# Altium schematic sheet Sheet2_v2.SchDoc
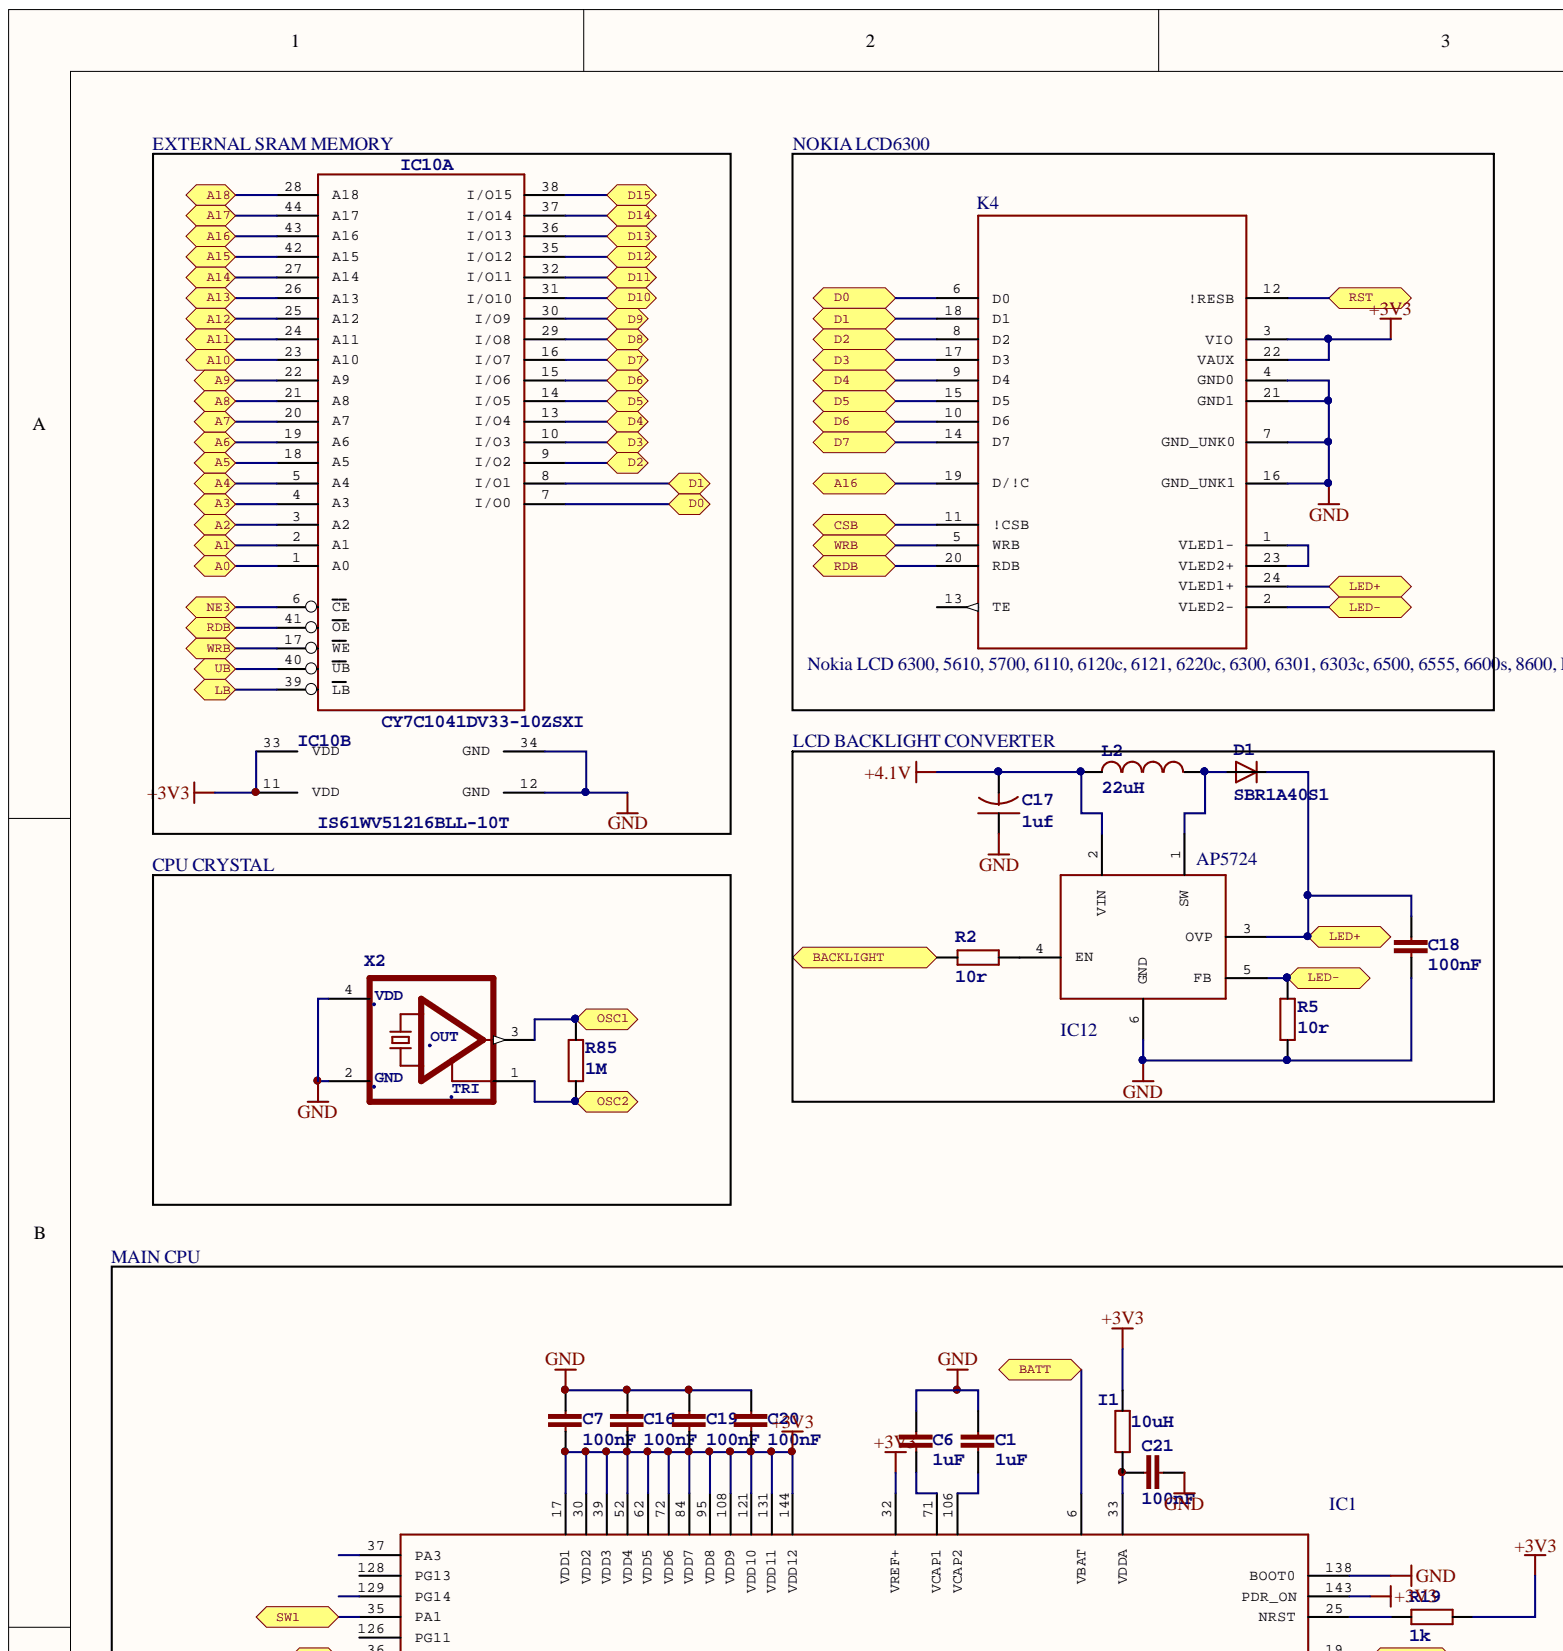
[diagram p0: Sheet2_v2.SchDoc, top left]
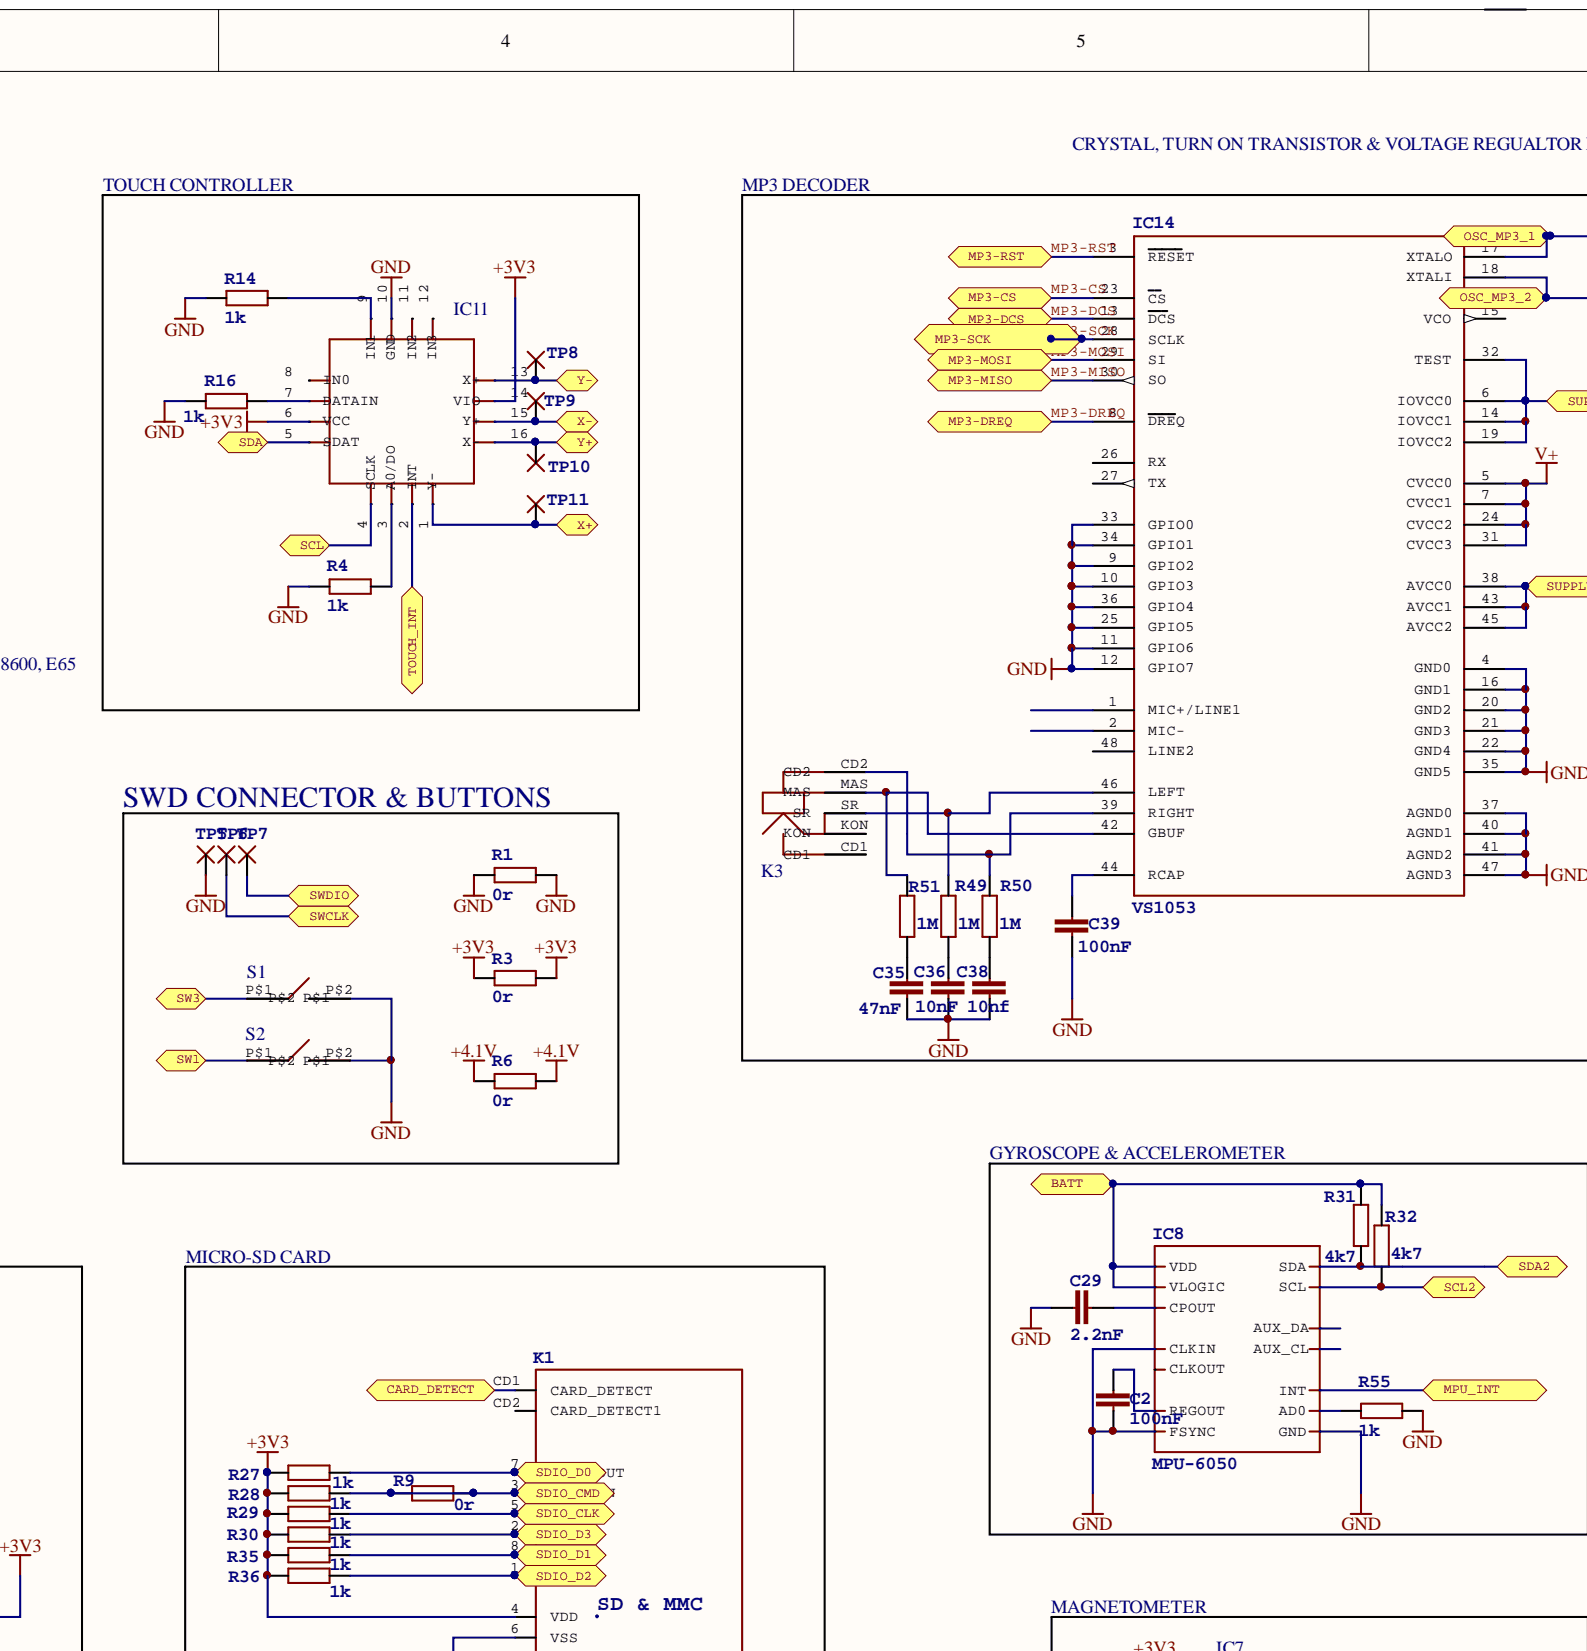
[diagram p1: Sheet2_v2.SchDoc, top centre]
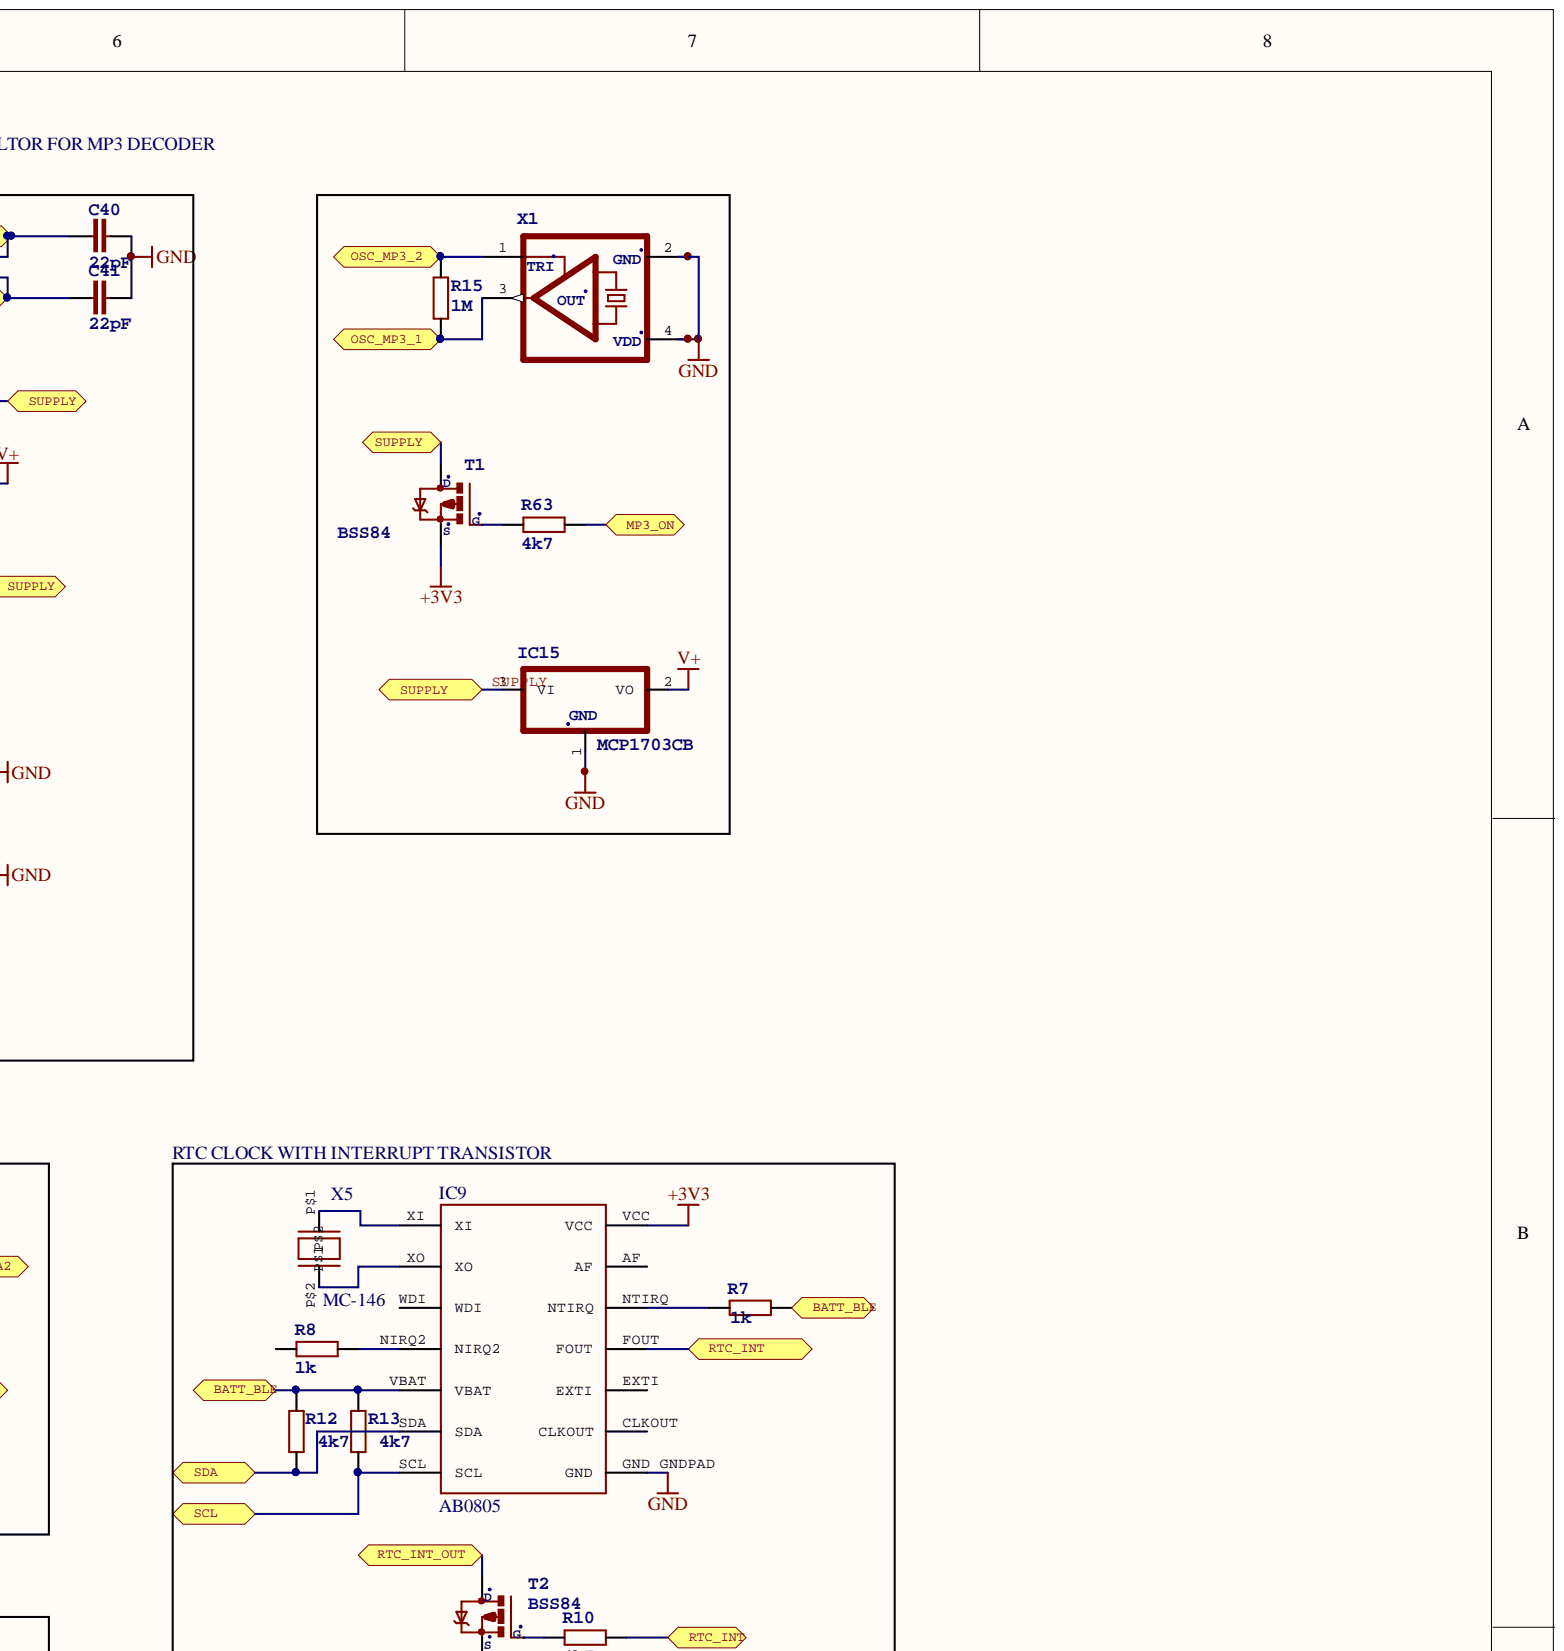
[diagram p2: Sheet2_v2.SchDoc, top right]
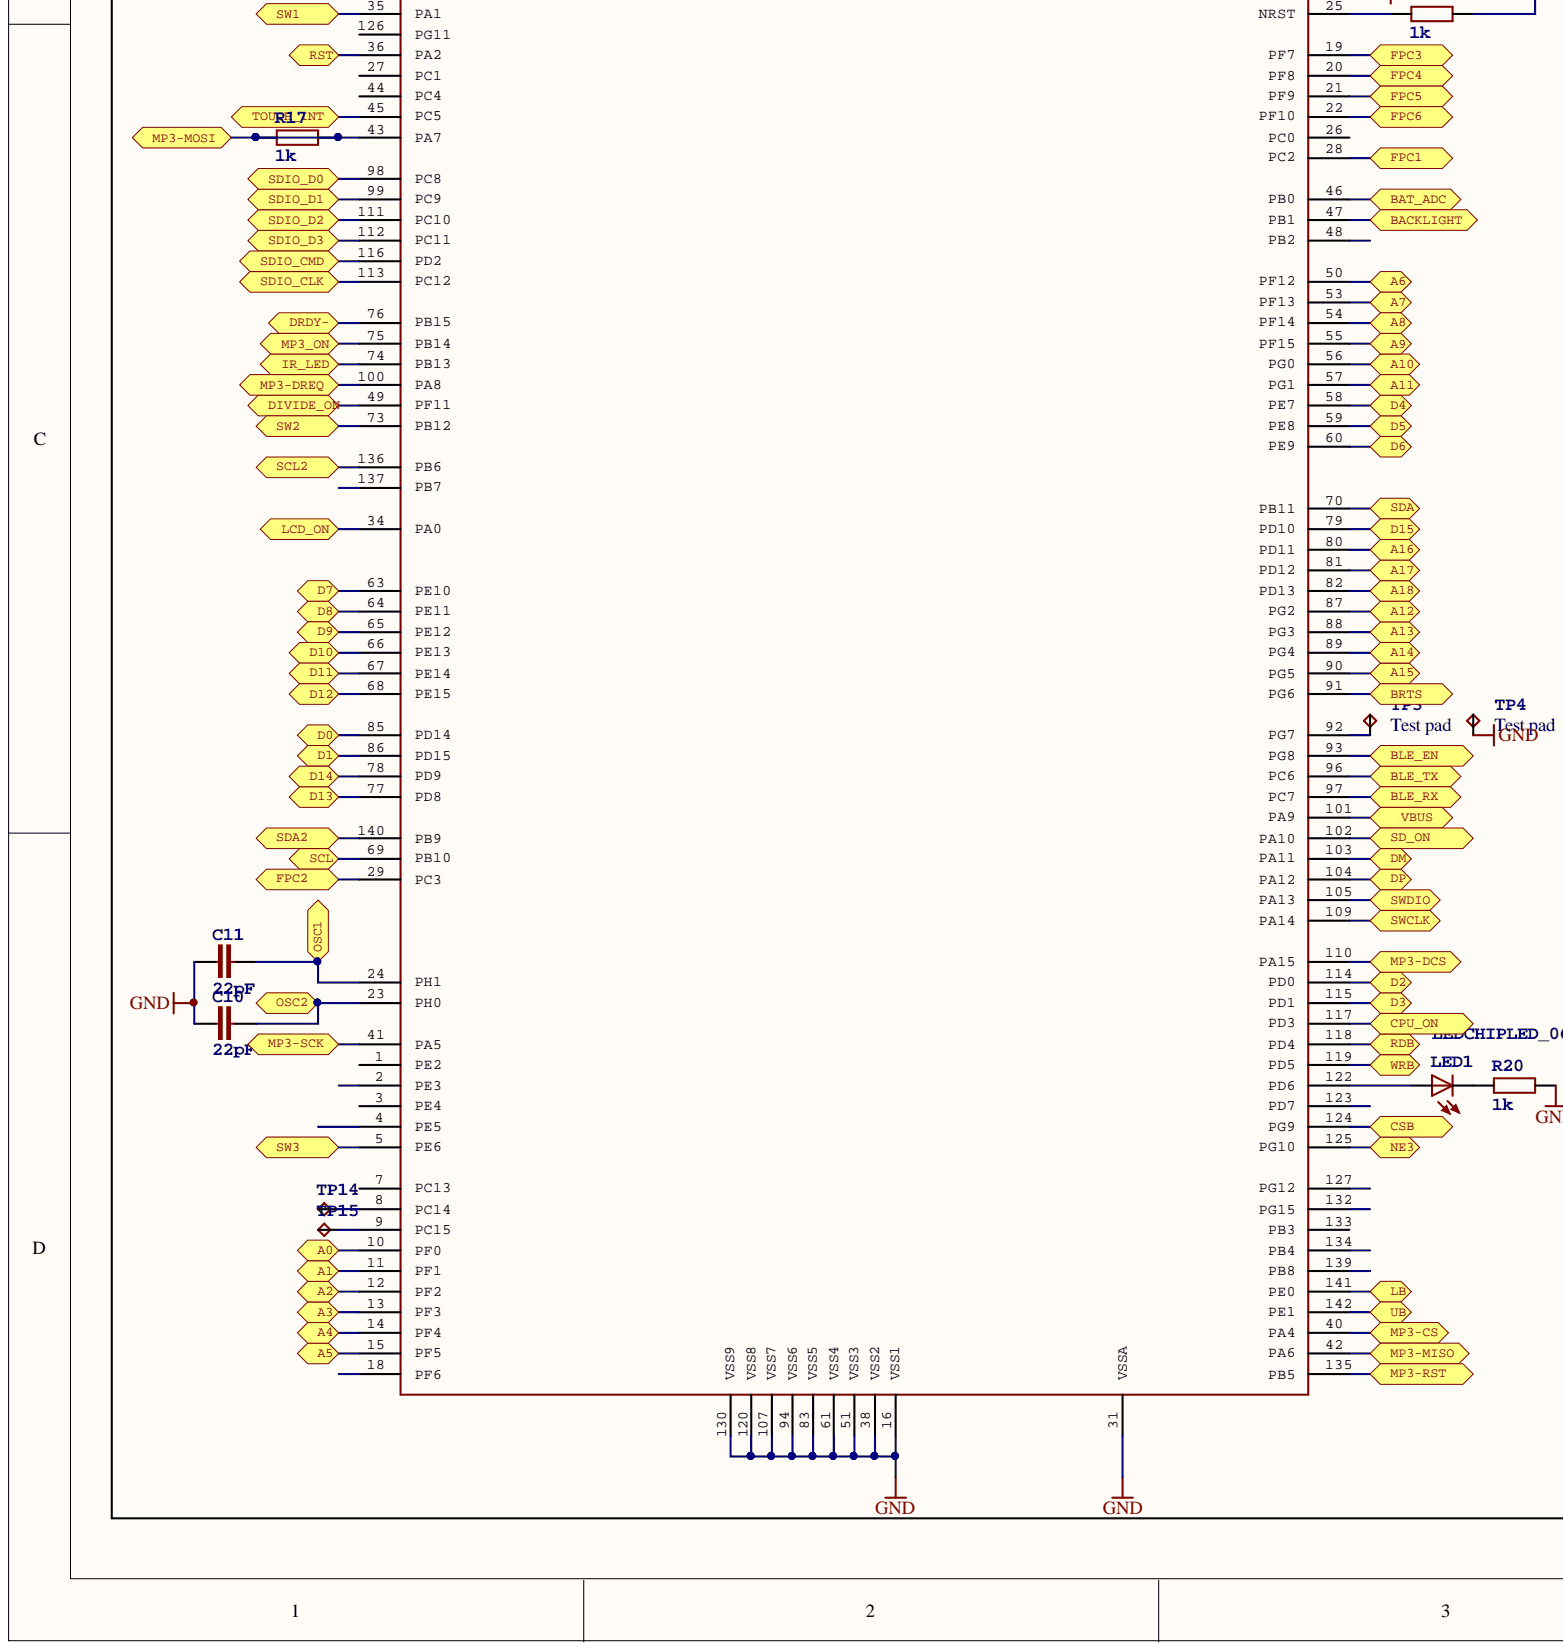
[diagram p3: Sheet2_v2.SchDoc, bottom left]
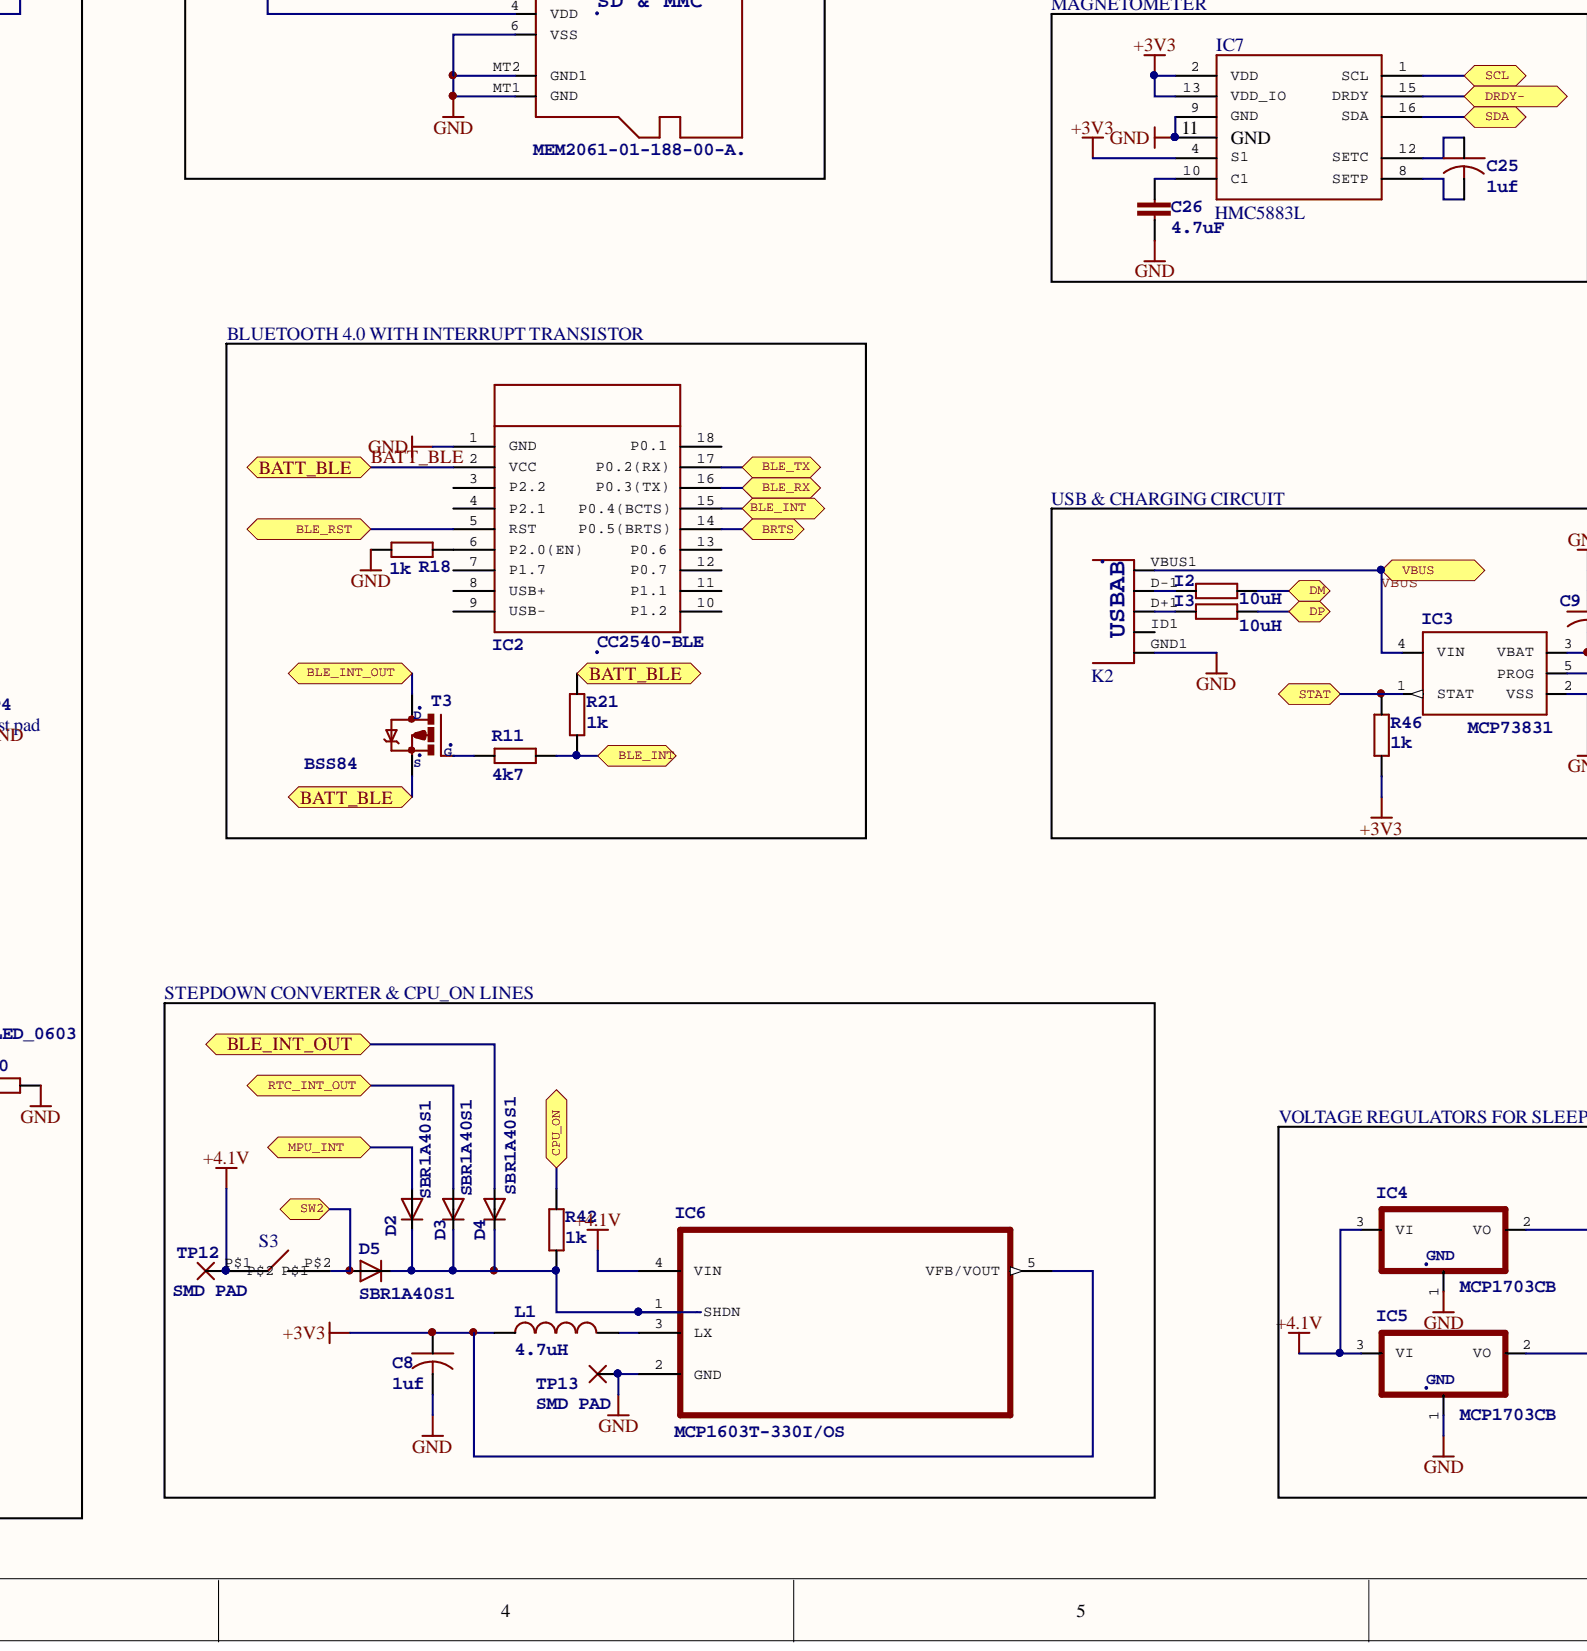
[diagram p4: Sheet2_v2.SchDoc, bottom centre]
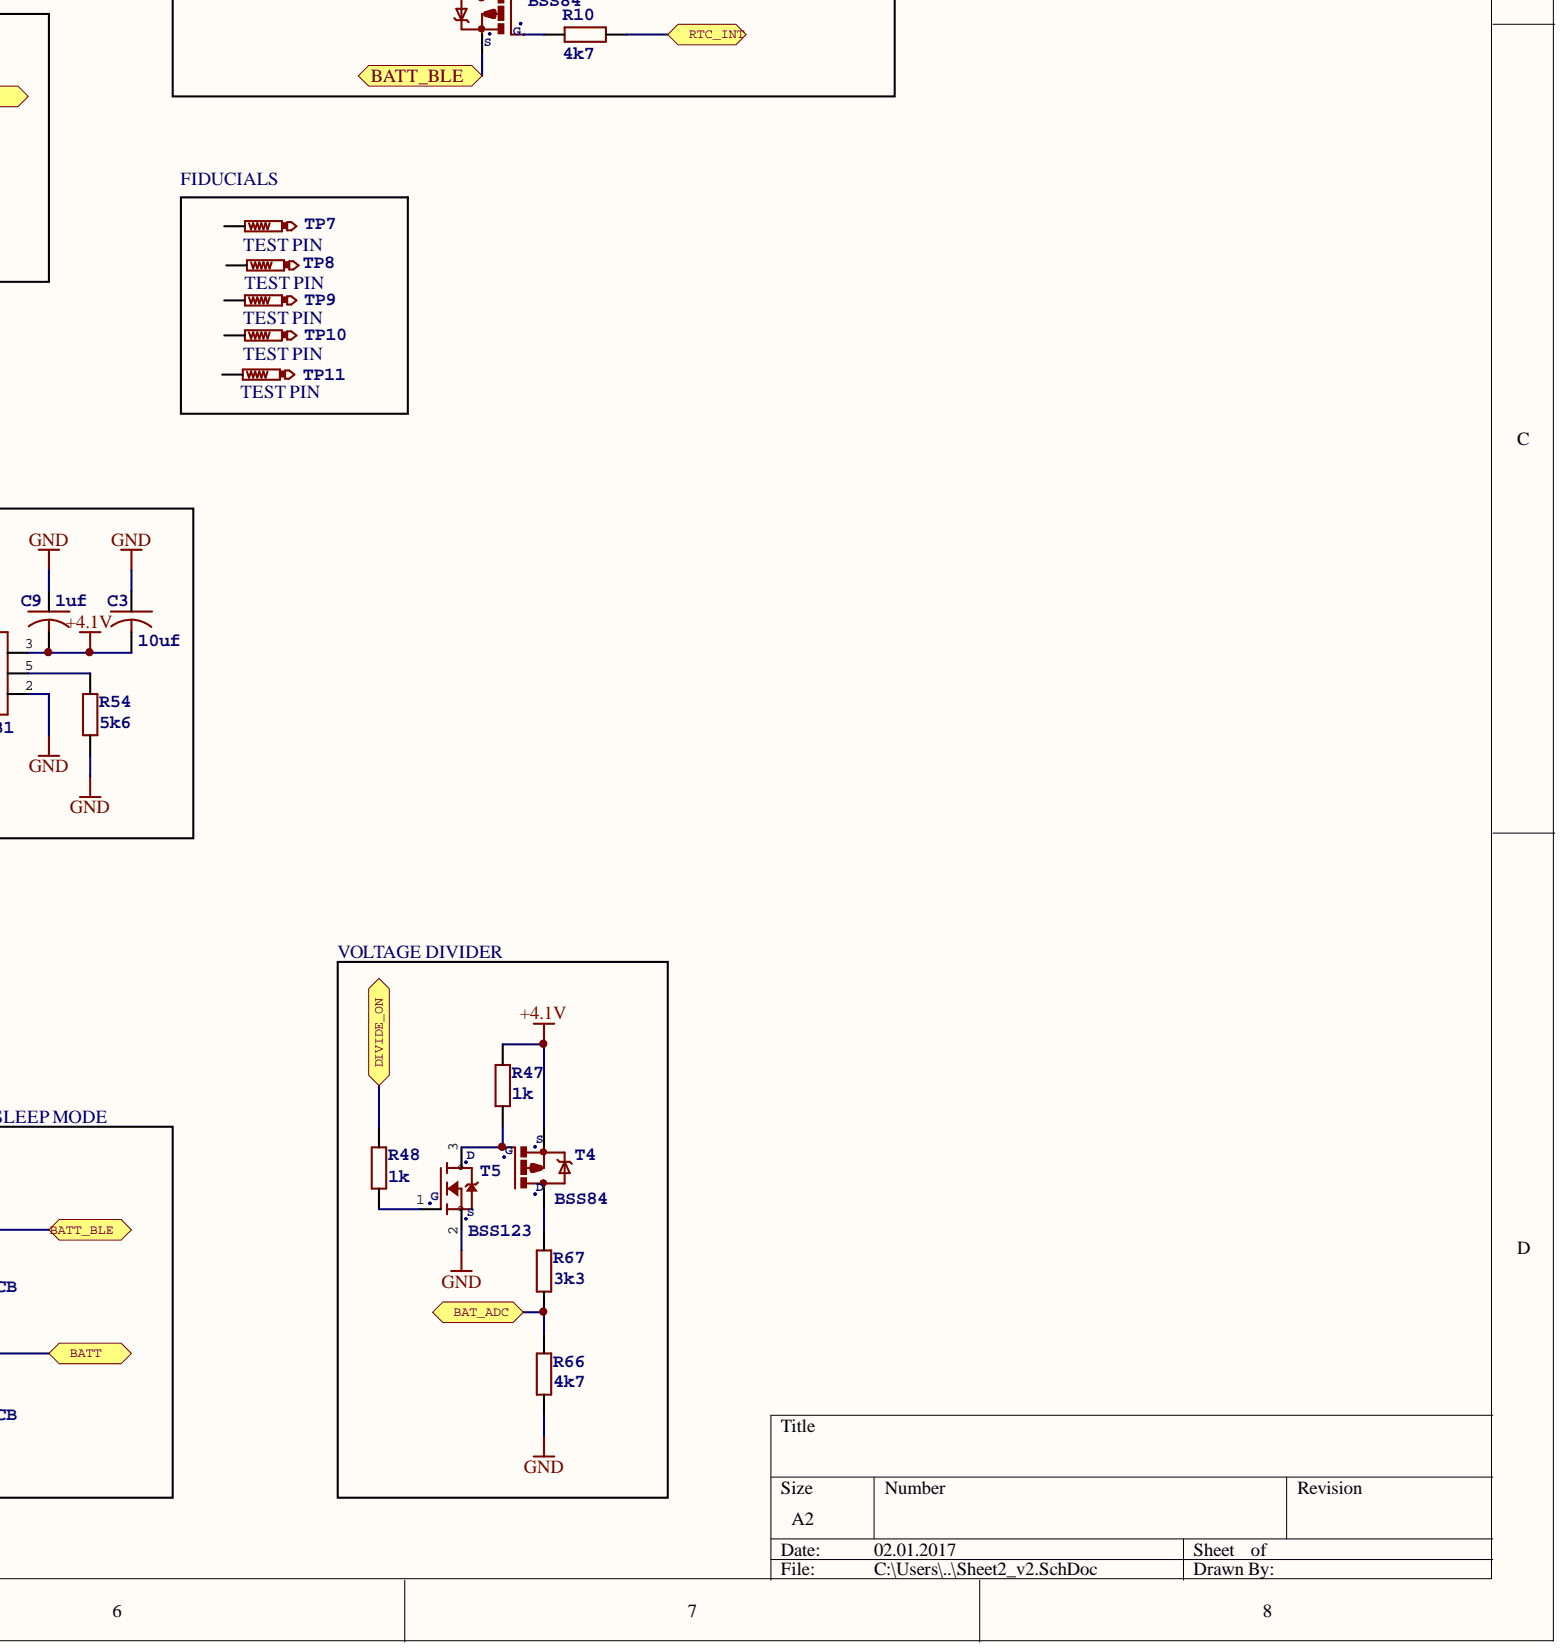
[diagram p5: Sheet2_v2.SchDoc, bottom right]

## Parts
C1  1uF  [C0402]
C2  100nF  [C0402]
C3  10uf  [C0805K]
C6  1uF  [C0402]
C7  100nF  [C0402]
C8  1uf  [C0805K]
C9  1uf  [C0805K]
C10  22pF  [C0402]
C11  22pF  [C0402]
C16  100nF  [C0402]
C17  1uf  [C0805K]
C18  100nF  [C0402]
C19  100nF  [C0402]
C20  100nF  [C0402]
C21  100nF  [C0402]
C25  1uf  [C0805K]
C26  4.7uF  [C0603K]
C29  2.2nF  [C0603K]
C35  47nF  [C0402]
C36  10nF  [C0402]
C38  10nf  [C0402]
C39  100nF  [C0402]
C40  22pF  [C0402]
C41  22pF  [C0402]
D1  SBR1A40S1  [SOD123]
D2  SBR1A40S1  [SOD123]
D3  SBR1A40S1  [SOD123]
D4  SBR1A40S1  [SOD123]
D5  SBR1A40S1  [SOD123]
I1  10uH  [R0402]
I2  10uH  [R0402]
I3  10uH  [R0402]
IC1  STM32F407ZGT6  [QFP50P2000X2000X160-144N]  (value not drawn)
IC2  RF-BM-S02  [CC2540-MINI-BLE]  (value not drawn)
IC3  MCP73831  [SOT23-5]
IC4  MCP1703CB  [SOT23]
IC5  MCP1703CB  [SOT23]
IC6  MCP1603T-330I/OS  [SOT95P280X110-5N]
IC7  HMC5883L  [LPCC16]
IC8  MPU-6050  [QFN.24.4X4]
IC9  AB0805  [QFN16]
IC10  CY7C1041DV33-10ZSXI  [TSOP-II-44]  (parts A,B)
IC11  STMPE811  [QFN-16]  (value not drawn)
IC12  AP5724  [DFN-62X2]
IC14  VS1053  [LQFP-48]
IC15  MCP1703CB  [SOT23]
K1  MEM2061-01-188-00-A.  SD&MMC: SD & MMC  [MICROSD]
K2  Microusb type B connector  [USB-MICRO-SMD]  (value not drawn)
K3  MOJ_JACK  [MOJ_JACK]  (value not drawn)
K4  Nokia LCD 6300, 5610, 5700, 6110, 6120c, 6121, 6220c, 6300, 6301, 6303c, 6500, 6555, 6600s, 8600, E65  [N6300_LCD_CONN]
L1  4.7uH  [L1812]
L2  22uH  [L1812]
LED1  LEDCHIPLED_0603  [CHIPLED_0603]
R1  0r  [R0402]
R2  10r  [R0603]
R3  0r  [R0402]
R4  1k  [R0402]
R5  10r  [R0603]
R6  0r  [R0402]
R7  1k  [R0402]
R8  1k  [R0402]
R9  0r  [R0402]
R10  4k7  [R0402]
R11  4k7  [R0402]
R12  4k7  [R0402]
R13  4k7  [R0402]
R14  1k  [R0402]
R15  1M  [R0402]
R16  1k  [R0402]
R17  1k  [R0402]
R18  1k  [R0402]
R19  1k  [R0402]
R20  1k  [R0402]
R21  1k  [R0402]
R27  1k  [R0402]
R28  1k  [R0402]
R29  1k  [R0402]
R30  1k  [R0402]
R31  4k7  [R0402]
R32  4k7  [R0402]
R35  1k  [R0402]
R36  1k  [R0402]
R42  1k  [R0402]
R46  1k  [R0402]
R47  1k  [R0402]
R48  1k  [R0402]
R49  1M  [R0402]
R50  1M  [R0402]
R51  1M  [R0402]
R54  5k6  [R0402]
R55  1k  [R0402]
R63  4k7  [R0402]
R66  4k7  [R0402]
R67  3k3  [R0402]
R85  1M  [R0402]
S1  TACTDR345H40B160  [SWITCHSMD]  (value not drawn)
S2  TACTDR345H40B160  [SWITCHSMD]  (value not drawn)
S3  TACTDR345H40B160  [SWITCHSMD]  (value not drawn)
T1  BSS84  [MICRO3]
T2  BSS84  [MICRO3]
T3  BSS84  [MICRO3]
T4  BSS84  [MICRO3]
T5  BSS123  [SOT23]
TP3  Test pad  [TP20R]
TP4  Test pad  [TP20R]
TP5  (no value)  [SMD1,27-2,54]
TP6  (no value)  [SMD1,27-2,54]
TP7  TEST PIN  [B1,27]
TP7  (no value)  [SMD1,27-2,54]
TP8  TEST PIN  [B1,27]
TP8  SMD PAD  [SMD1,27-2,54]  (value not drawn)
TP9  TEST PIN  [B1,27]
TP9  SMD PAD  [SMD1,27-2,54]  (value not drawn)
TP10  TEST PIN  [B1,27]
TP10  SMD PAD  [SMD1,27-2,54]  (value not drawn)
TP11  TEST PIN  [B1,27]
TP11  SMD PAD  [SMD1,27-2,54]  (value not drawn)
TP12  SMD PAD  [SMD2,54-5,08]
TP13  SMD PAD  [SMD2,54-5,08]
TP14  TPTP08SQ  [TP08SQ]  (value not drawn)
TP15  TPTP08SQ  [TP08SQ]  (value not drawn)
X1  X1G0041710019 SG-210STF 12.288MHZ  [ASE]  (value not drawn)
X2  E3SB16.0000F09G11AE  [ASE]  (value not drawn)
X5  MC-146  [CRYSTAL32.76KHZ]

## Ports
A0, A1, A2, A3, A4, A5, A6, A7, A8, A9, A10, A11, A12, A13, A14, A15, A16, A17, A18, BACKLIGHT, BAT_ADC, BATT, BATT_BLE, BLE_EN, BLE_INT, BLE_INT_OUT, BLE_RST, BLE_RX, BLE_TX, BRTS, CARD_DETECT, CPU_ON, CSB, D0, D1, D2, D3, D4, D5, D6, D7, D8, D9, D10, D11, D12, D13, D14, D15, DIVIDE_ON, DM, DP, DRDY-, FPC1, FPC2, FPC3, FPC4, FPC5, FPC6, IR_LED, LB, LCD_ON, LED+, LED-, MP3-CS, MP3-DCS, MP3-DREQ, MP3-MISO, MP3-MOSI, MP3-RST, MP3-SCK, MP3_ON, MPU_INT, NE3, OSC1, OSC2, OSC_MP3_1, OSC_MP3_2, RDB, RST, RTC_INT, RTC_INT_OUT, SCL, SCL2, SD_ON, SDA, SDA2, SDIO_CLK, SDIO_CMD, SDIO_D0, SDIO_D1, SDIO_D2, SDIO_D3, STAT, SUPPLY, SW1, SW2, SW3, SWCLK, SWDIO, TOUCH_INT, UB, VBUS, WRB, X+, X-, Y+, Y-

## Nets
+3V3: C7.1 C8.1 C16.1 C19.1 C20.1 I1.1 IC1.17(VDD1) IC1.30(VDD2) IC1.32 IC1.39 IC1.52(VDD4) IC1.62(VDD5) IC1.72(VDD6) IC1.84(VDD7) IC1.95(VDD8) IC1.108(VDD9) IC1.121(VDD10) IC1.131(VDD11) IC1.143(PDR_ON) IC1.144(VDD12) IC6.5(VFB/VOUT) IC7.2(VDD) IC7.4(S1) IC7.13(VDD_IO) IC9.VCC IC10.11(VDD) IC10.33(VDD) IC11.6 IC11.14 K1.4(VDD) K4.3(VIO) K4.22(VAUX) L1.1 R3.1 R3.2 R19.1 R27.2 R28.2 R29.2 R30.2 R35.2 R36.2 R46.2 T1.2
+4.1V: C3.2 C9.2 C17.2 IC3.3(VBAT) IC4.3 IC5.3 IC6.4(VIN) IC12.2(VIN) L2.1 R6.1 R6.2 R47.1 S3.P$1 T4.2 TP12.1
GND: C1.2 C2.1 C3.1 C6.1 C7.2 C8.2 C9.1 C10.2 C11.2 C16.2 C17.1 C18.1 C19.2 C20.2 C21.1 C26.1 C29.2 C35.2 C36.2 C38.2 C39.2 C40.2 C41.2 IC1.16 IC1.31(VSSA) IC1.38(VSS2) IC1.51(VSS3) IC1.61(VSS4) IC1.83(VSS5) IC1.94(VSS6) IC1.107(VSS7) IC1.120(VSS8) IC1.130(VSS9) IC1.138(BOOT0) IC2.1(GND) IC3.2(VSS) IC4.1 IC5.1 IC6.2(GND) IC7.9(GND) IC7.11(GND) IC8.1 IC8.11 IC8.18 IC9.GND GNDPAD(GND) IC10.12(GND) IC10.34(GND) IC11.10 IC12.6(GND) IC14.4(GND0) IC14.9(GPIO2) IC14.10(GPIO3) IC14.11(GPIO6) IC14.12(GPIO7) IC14.16(GND1) IC14.20(GND2) IC14.21(GND3) IC14.22(GND4) IC14.25(GPIO5) IC14.33(GPIO0) IC14.34(GPIO1) IC14.35(GND5) IC14.36(GPIO4) IC14.37(AGND0) IC14.40(AGND1) IC14.41(AGND2) IC14.47(AGND3) IC15.1 K1.6(VSS) K1.MT1(GND) K1.MT2(GND1) K2.GND1 K4.4(GND0) K4.7(GND_UNK0) K4.16(GND_UNK1) K4.21(GND1) R1.1 R1.2 R4.1 R5.2 R14.1 R16.1 R18.2 R20.1 R54.2 R55.2 R66.1 S1.P$2 S2.P$2 T5.2 TP4.TP TP5.1 TP13.1 X1.2(GND) X1.4(VDD) X2.2(GND) X2.4(VDD)
V+: IC14.5(CVCC0) IC14.7(CVCC1) IC14.24(CVCC2) IC14.31(CVCC3) IC15.2
A0: IC1.10(PF0) IC10.1(A0) ; port A0
A1: IC1.11(PF1) IC10.2(A1) ; port A1
A2: IC1.12(PF2) IC10.3(A2) ; port A2
A3: IC1.13(PF3) IC10.4(A3) ; port A3
A4: IC1.14(PF4) IC10.5(A4) ; port A4
A5: IC1.15(PF5) IC10.18(A5) ; port A5
A6: IC1.50(PF12) IC10.19(A6) ; port A6
A7: IC1.53(PF13) IC10.20(A7) ; port A7
A8: IC1.54(PF14) IC10.21(A8) ; port A8
A9: IC1.55(PF15) IC10.22(A9) ; port A9
A10: IC1.56(PG0) IC10.23(A10) ; port A10
A11: IC1.57(PG1) IC10.24(A11) ; port A11
A12: IC1.87(PG2) IC10.25(A12) ; port A12
A13: IC1.88(PG3) IC10.26(A13) ; port A13
A14: IC1.89(PG4) IC10.27(A14) ; port A14
A15: IC1.90(PG5) IC10.42(A15) ; port A15
A16: IC1.80(PD11) IC10.43(A16) K4.19(D/!C) ; port A16
A17: IC1.81(PD12) IC10.44(A17) ; port A17
A18: IC1.82(PD13) IC10.28(A18) ; port A18
BACKLIGHT: IC1.47(PB1) R2.1 ; port BACKLIGHT
BAT_ADC: IC1.46(PB0) R66.2 R67.1 ; port BAT_ADC
BATT: IC1.6(VBAT) IC5.2 IC8.8 IC8.13 R31.2 R32.2 ; port BATT
BATT_BLE: IC2.2(VCC) IC4.2 IC9.VBAT R7.1 R12.2 R13.2 R21.1 T2.2 T3.2 ; port BATT_BLE
BLE_EN: IC1.93(PG8) ; port BLE_EN
BLE_INT: IC2.15(P0.4(BCTS)) R11.1 R21.2 ; port BLE_INT
BLE_INT_OUT: D4.A T3.3 ; port BLE_INT_OUT
BLE_RST: IC2.5(RST) ; port BLE_RST
BLE_RX: IC1.97(PC7) IC2.16(P0.3(TX)) ; port BLE_RX
BLE_TX: IC1.96(PC6) IC2.17(P0.2(RX)) ; port BLE_TX
BRTS: IC1.91(PG6) IC2.14(P0.5(BRTS)) ; port BRTS
CARD_DETECT: K1.CD1(CARD_DETECT) ; port CARD_DETECT
CPU_ON: IC1.117(PD3) R42.2 ; port CPU_ON
CSB: IC1.124(PG9) K4.11(!CSB) ; port CSB
D0: IC1.85(PD14) IC10.7(I/O0) K4.6(D0) ; port D0
D1: IC1.86(PD15) IC10.8(I/O1) K4.18(D1) ; port D1
D2: IC1.114(PD0) IC10.9(I/O2) K4.8(D2) ; port D2
D3: IC1.115(PD1) IC10.10(I/O3) K4.17(D3) ; port D3
D4: IC1.58(PE7) IC10.13(I/O4) K4.9(D4) ; port D4
D5: IC1.59(PE8) IC10.14(I/O5) K4.15(D5) ; port D5
D6: IC1.60(PE9) IC10.15(I/O6) K4.10(D6) ; port D6
D7: IC1.63(PE10) IC10.16(I/O7) K4.14(D7) ; port D7
D8: IC1.64(PE11) IC10.29(I/O8) ; port D8
D9: IC1.65(PE12) IC10.30(I/O9) ; port D9
D10: IC1.66(PE13) IC10.31(I/O10) ; port D10
D11: IC1.67(PE14) IC10.32(I/O11) ; port D11
D12: IC1.68(PE15) IC10.35(I/O12) ; port D12
D13: IC1.77(PD8) IC10.36(I/O13) ; port D13
D14: IC1.78(PD9) IC10.37(I/O14) ; port D14
D15: IC1.79(PD10) IC10.38(I/O15) ; port D15
DIVIDE_ON: IC1.49(PF11) R48.2 ; port DIVIDE_ON
DM: I2.1 IC1.103(PA11) ; port DM
DP: I3.1 IC1.104(PA12) ; port DP
DRDY-: IC1.76(PB15) IC7.15(DRDY) ; port DRDY-
FPC1: IC1.28(PC2) ; port FPC1
FPC2: IC1.29(PC3) ; port FPC2
FPC3: IC1.19(PF7) ; port FPC3
FPC4: IC1.20(PF8) ; port FPC4
FPC5: IC1.21(PF9) ; port FPC5
FPC6: IC1.22(PF10) ; port FPC6
IR_LED: IC1.74(PB13) ; port IR_LED
LB: IC1.141(PE0) IC10.39(LB) ; port LB
LCD_ON: IC1.34(PA0) ; port LCD_ON
MP3-CS: IC1.40(PA4) IC14.23(CS) ; port MP3-CS
MP3-DCS: IC1.110(PA15) IC14.13(DCS) ; port MP3-DCS
MP3-DREQ: IC1.100(PA8) IC14.8(DREQ) ; port MP3-DREQ
MP3-MISO: IC1.42(PA6) IC14.30(SO) ; port MP3-MISO
MP3-MOSI: IC1.43(PA7) IC14.29(SI) R17.1 R17.2 ; port MP3-MOSI
MP3-RST: IC1.135(PB5) IC14.3(RESET) ; port MP3-RST
MP3-SCK: IC1.41(PA5) IC14.28(SCLK) ; port MP3-SCK
MP3_ON: IC1.75(PB14) R63.1 ; port MP3_ON
MPU_INT: D2.A IC8.12 ; port MPU_INT
NE3: IC1.125(PG10) IC10.6(CE) ; port NE3
OSC1: C11.1 IC1.24(PH1) R85.2 X2.3(OUT) ; port OSC1
OSC2: C10.1 IC1.23(PH0) R85.1 X2.1 ; port OSC2
OSC_MP3_1: C40.1 IC14.17(XTALO) R15.2 X1.3 ; port OSC_MP3_1
OSC_MP3_2: C41.1 IC14.18(XTALI) R15.1 X1.1 ; port OSC_MP3_2
RDB: IC1.118(PD4) IC10.41(OE) K4.20(RDB) ; port RDB
RST: IC1.36(PA2) K4.12(!RESB) ; port RST
RTC_INT: IC9.FOUT R10.1 ; port RTC_INT
RTC_INT_OUT: D3.A T2.3 ; port RTC_INT_OUT
SCL: IC1.69(PB10) IC7.1(SCL) IC9.SCL IC11.4 R13.1 ; port SCL
SCL2: IC1.136(PB6) IC8.23 R32.1 ; port SCL2
SD_ON: IC1.102(PA10) ; port SD_ON
SDA: IC1.70(PB11) IC7.16(SDA) IC9.SDA IC11.5 R12.1 ; port SDA
SDA2: IC1.140(PB9) IC8.24 R31.1 ; port SDA2
SDIO_CLK: IC1.113(PC12) K1.5(SDIO_CLK) R29.1 ; port SDIO_CLK
SDIO_CMD: IC1.116(PD2) K1.3(SDIO_CMD) R9.1 R9.2 R28.1 ; port SDIO_CMD
SDIO_D0: IC1.98(PC8) K1.7(SDIO_D0) R27.1 ; port SDIO_D0
SDIO_D1: IC1.99(PC9) K1.8(SDIO_D1) R35.1 ; port SDIO_D1
SDIO_D2: IC1.111(PC10) K1.1(SDIO_D2) R36.1 ; port SDIO_D2
SDIO_D3: IC1.112(PC11) K1.2(SDIO_D3) R30.1 ; port SDIO_D3
STAT: IC3.1(STAT) R46.1 ; port STAT
SUPPLY: IC14.6(IOVCC0) IC14.14(IOVCC1) IC14.19(IOVCC2) IC14.32(TEST) IC14.38(AVCC0) IC14.43(AVCC1) IC14.45(AVCC2) IC15.3 T1.3 ; port SUPPLY
SW1: IC1.35(PA1) S2.P$1 ; port SW1
SW2: D5.A IC1.73(PB12) S3.P$2 ; port SW2
SW3: IC1.5(PE6) S1.P$1 ; port SW3
SWCLK: IC1.109(PA14) TP6.1 ; port SWCLK
SWDIO: IC1.105(PA13) TP7.1 ; port SWDIO
TOUCH_INT: IC1.45(PC5) IC11.2 ; port TOUCH_INT
UB: IC1.142(PE1) IC10.40(UB) ; port UB
VBUS: IC1.101(PA9) IC3.4(VIN) K2.VBUS1 ; port VBUS
WRB: IC1.119(PD5) IC10.17(WE) K4.5(WRB) ; port WRB
NetC1_1: C1.1 IC1.106(VCAP2)
NetC2_2: C2.2 IC8.10
NetC6_2: C6.2 IC1.71(VCAP1)
NetC18_2: C18.2 D1.C IC12.3(OVP) K4.24(VLED1+)
NetC21_2: C21.2 I1.2 IC1.33(VDDA)
NetC25_1: C25.1 IC7.12(SETC)
NetC25_2: C25.2 IC7.8(SETP)
NetC26_2: C26.2 IC7.10(C1)
NetC29_1: C29.1 IC8.20
NetC35_1: C35.1 R51.1
NetC36_1: C36.1 R49.1
NetC38_1: C38.1 R50.1
NetC39_1: C39.1 IC14.44(RCAP)
NetD1_A: D1.A IC12.1(SW) L2.2
NetD2_C: D2.C D3.C D4.C D5.C IC6.1(~SHDN) R42.1
NetI2_2: I2.2 K2.D-1
NetI3_2: I3.2 K2.D+1
NetIC1_8: IC1.8(PC14) TP14.TP
NetIC1_9: IC1.9(PC15) TP15.TP
NetIC1_25: IC1.25(NRST) R19.2
NetIC1_92: IC1.92(PG7) TP3.TP
NetIC1_122: IC1.122(PD6) LED1.A
NetIC2_6: IC2.6(P2.0(EN)) R18.1
NetIC3_5: IC3.5(PROG) R54.1
NetIC6_3: IC6.3(LX) L1.2
NetIC8_9: IC8.9 R55.1
NetIC9_NIRQ2: IC9.NIRQ2 R8.1
NetIC9_NTIRQ: IC9.NTIRQ R7.2
NetIC9_XI: IC9.XI X5.P$1
NetIC9_XO: IC9.XO X5.P$2
NetIC11_1: IC11.1 TP11.1
NetIC11_3: IC11.3 R4.2
NetIC11_7: IC11.7 R16.2
NetIC11_9: IC11.9(IN1) R14.2
NetIC11_13: IC11.13 TP8.1
NetIC11_15: IC11.15 TP9.1
NetIC11_16: IC11.16 TP10.1
NetIC12_4: IC12.4(EN) R2.2
NetIC12_5: IC12.5(FB) K4.2(VLED2-) R5.1
NetIC14_39: IC14.39(RIGHT) K3.CD2 R50.2
NetIC14_42: IC14.42(GBUF) K3.MAS R51.2
NetIC14_46: IC14.46(LEFT) K3.SR R49.2
NetK4_1: K4.1(VLED1-) K4.23(VLED2+)
NetLED1_C: LED1.C R20.2
NetR10_2: R10.2 T2.1
NetR11_2: R11.2 T3.1
NetR47_2: R47.2 T4.1 T5.3
NetR48_1: R48.1 T5.1
NetR63_2: R63.2 T1.1
NetR67_2: R67.2 T4.3
N00167:

Not connected: IC1 1(PE2) 2(PE3) 3(PE4) 4(PE5) 7(PC13) 18(PF6) 26(PC0) 27(PC1) 37(PA3) 44(PC4) 48(PB2) 123(PD7) 126(PG11) 127(PG12) 128(PG13) 129(PG14) 132(PG15) 133(PB3) 134(PB4) 137(PB7) 139(PB8); IC2 3(P2.2) 4(P2.1) 7(P1.7) 8(USB+) 9(USB-) 10(P1.2) 11(P1.1) 12(P0.7) 13(P0.6) 18(P0.1); IC8 6 7 22; IC9 AF CLKOUT EXTI WDI; IC11 8 11(IN2) 12(IN3); IC14 1(MIC+/LINE1) 2(MIC-) 15(VCO) 26(RX) 27(TX) 48(LINE2); K1 CD2(CARD_DETECT1); K2 ID1; K3 CD1 KON; K4 13(TE); R8 2; TP7 TP; TP8 TP; TP9 TP; TP10 TP; TP11 TP
